# Altium schematic sheet ToolDoorController.SchDoc
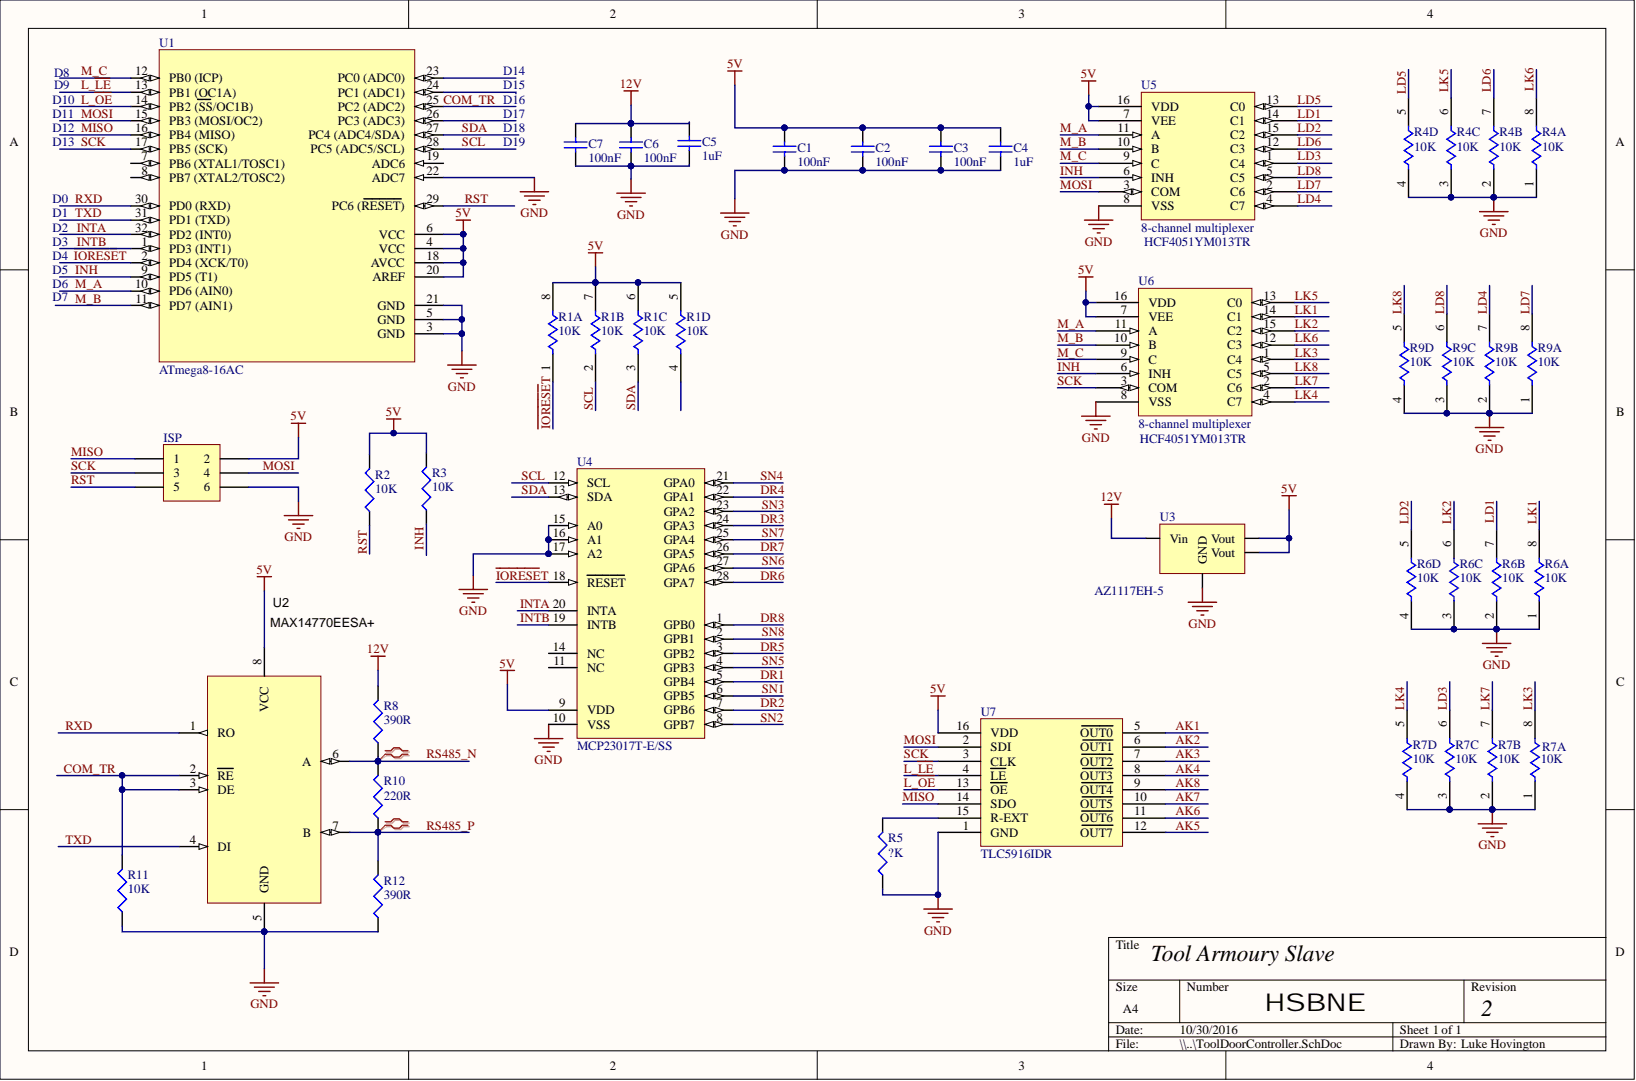
[diagram p0: ToolDoorController.SchDoc]

## Parts
C1  100nF  [C1206]
C2  100nF  [C1206]
C3  100nF  [C1206]
C4  1uF  [C1206]
C5  1uF  [C1206]
C6  100nF  [C1206]
C7  100nF  [C1206]
ISP  ISP  [HDR2X3]
R1  10K  [SO8_S]  (parts A,B,C,D)
R2  10K  [C1206]
R3  10K  [C1206]
R4  10K  [SO8_S]  (parts A,B,C,D)
R5  ?K  [C1206]
R6  10K  [SO8_S]  (parts A,B,C,D)
R7  10K  [SO8_S]  (parts A,B,C,D)
R8  390R  [C1206]  (no BOM)
R9  10K  [SO8_S]  (parts A,B,C,D)
R10  220R  [C1206]  (no BOM)
R11  10K  [C1206]
R12  390R  [C1206]  (no BOM)
U1  ATmega8-16AC  [32A_N]
U2  MAX14770EESA+  [8S1_L]
U3  AZ1117EH-5  [SOT-223]
U4  MCP23017T-E/SS  [SSOP-SS28_N]
U5  8-channel multiplexer  Manufacturer Part Number: HCF4051YM013TR  [16S1_M]
U6  8-channel multiplexer  Manufacturer Part Number: HCF4051YM013TR  [16S1_M]
U7  TLC5916IDR  [DB016]

## Nets
5V: C1.2 C2.2 C3.2 C4.2 ISP.2 R1.5 R1.6 R1.7 R1.8 R2.2 R3.2 U1.4(VCC) U1.6(VCC) U1.18(AVCC) U1.20(AREF) U2.8(VCC) U3.2(Vout) U3.4(Vout) U4.9(VDD) U5.7(VEE) U5.16(VDD) U6.7(VEE) U6.16(VDD) U7.16(VDD)
12V: C5.2 C6.2 C7.2 R8.2 U3.3(Vin)
GND: C1.1 C2.1 C3.1 C4.1 C5.1 C6.1 C7.1 ISP.6 R4.1 R4.2 R4.3 R4.4 R5.1 R6.1 R6.2 R6.3 R6.4 R7.1 R7.2 R7.3 R7.4 R9.1 R9.2 R9.3 R9.4 R11.1 R12.1 U1.3(GND) U1.5(GND) U1.21(GND) U1.22(ADC7) U2.5(GND) U3.1(GND) U4.10(VSS) U4.15(A0) U4.16(A1) U4.17(A2) U5.8(VSS) U6.8(VSS) U7.1(GND)
AK1: U7.5(OUT0)
AK2: U7.6(OUT1)
AK3: U7.7(OUT2)
AK4: U7.8(OUT3)
AK5: U7.12(OUT7)
AK6: U7.11(OUT6)
AK7: U7.10(OUT5)
AK8: U7.9(OUT4)
COM_TR: R11.2 U1.25(PC2_(ADC2)) U2.2(RE) U2.3(DE)
DR1: U4.5(GPB4)
DR2: U4.7(GPB6)
DR3: U4.24(GPA3)
DR4: U4.22(GPA1)
DR5: U4.3(GPB2)
DR6: U4.28(GPA7)
DR7: U4.26(GPA5)
DR8: U4.1(GPB0)
~{IORESE}T: R1.1 U1.2(PD4_(XCK/T0)) U4.18(RESET)
INH: R3.1 U1.9(PD5_(T1)) U5.6(INH) U6.6(INH)
INTA: U1.32(PD2_(INT0)) U4.20(INTA)
INTB: U1.1(PD3_(INT1)) U4.19(INTB)
L_~{LE}: U1.13(PB1_(OC1A)) U7.4(LE)
L_~{OE}: U1.14(PB2_(SS/OC1B)) U7.13(OE)
LD1: R6.7 U5.14(C1)
LD2: R6.5 U5.15(C2)
LD3: R7.6 U5.1(C4)
LD4: R9.7 U5.4(C7)
LD5: R4.5 U5.13(C0)
LD6: R4.7 U5.12(C3)
LD7: R9.8 U5.2(C6)
LD8: R9.6 U5.5(C5)
LK1: R6.8 U6.14(C1)
LK2: R6.6 U6.15(C2)
LK3: R7.8 U6.1(C4)
LK4: R7.5 U6.4(C7)
LK5: R4.6 U6.13(C0)
LK6: R4.8 U6.12(C3)
LK7: R7.7 U6.2(C6)
LK8: R9.5 U6.5(C5)
M_A: U1.10(PD6_(AIN0)) U5.11(A) U6.11(A)
M_B: U1.11(PD7_(AIN1)) U5.10(B) U6.10(B)
M_C: U1.12(PB0_(ICP)) U5.9(C) U6.9(C)
MISO: ISP.1 U1.16(PB4_(MISO)) U7.14(SDO)
MOSI: ISP.4 U1.15(PB3_(MOSI/OC2)) U5.3(COM) U7.2(SDI)
RS485_N: R8.1 R10.2 U2.6(A)
RS485_P: R10.1 R12.2 U2.7(B)
RST: ISP.5 R2.1 U1.29(PC6_(RESET))
RXD: U1.30(PD0_(RXD)) U2.1(RO)
SCK: ISP.3 U1.17(PB5_(SCK)) U6.3(COM) U7.3(CLK)
SCL: R1.2 U1.28(PC5_(ADC5/SCL)) U4.12(SCL)
SDA: R1.3 U1.27(PC4_(ADC4/SDA)) U4.13(SDA)
SN1: U4.6(GPB5)
SN2: U4.8(GPB7)
SN3: U4.23(GPA2)
SN4: U4.21(GPA0)
SN5: U4.4(GPB3)
SN6: U4.27(GPA6)
SN7: U4.25(GPA4)
SN8: U4.2(GPB1)
TXD: U1.31(PD1_(TXD)) U2.4(DI)
NetR5_2: R5.2 U7.15(R-EXT)

Not connected: R1 4; U1 7(PB6_(XTAL1/TOSC1)) 8(PB7_(XTAL2/TOSC2)) 19(ADC6) 23(PC0_(ADC0)) 24(PC1_(ADC1)) 26(PC3_(ADC3)); U4 11(NC) 14(NC)

## Library data of the parts
C1-C3 C6 C7 = Cap 100nF: Capacitor (Semiconductor SIM Model); Component Kind: Standard; LatestRevisionDate: 29-May-2009; LatestRevisionNote: IPC-7351 Footprint Added.; PackageDescription: Chip Capacitor; PackageReference: C1206; Published: 8-Jun-2000; Signal Integrity: Cap Semi; Simulation: CAP; Supplier 1: Digi-Key; Supplier 2: Mouser; Supplier Part Number 1: 399-1249-1-ND; Supplier Part Number 2: 80-C1206C104K5R; Value: 100nF; Library Name: ToolDoorController.SCHLIB; Publisher: Altium Limited
C4 C5 = Cap 1uF: Capacitor (Semiconductor SIM Model); Component Kind: Standard; LatestRevisionDate: 29-May-2009; LatestRevisionNote: IPC-7351 Footprint Added.; PackageDescription: Chip Capacitor; PackageReference: C1206; Published: 8-Jun-2000; Signal Integrity: Cap Semi; Simulation: CAP; Supplier 1: Mouser; Supplier 2: Digi-Key; Supplier Part Number 1: 80-C1206C105K5R; Supplier Part Number 2: 399-8150-1-ND; Value: 1uF; Library Name: ToolDoorController.SCHLIB; Publisher: Altium Limited
ISP = Header 3X2: Header, 3-Pin, Dual row; Component Kind: Standard; LatestRevisionDate: 17-Jul-2002; LatestRevisionNote: Re-released for DXP Platform.; Signal Integrity: Connector; Supplier 1: Digi-Key; Supplier 2: Mouser; Supplier Part Number 1: 952-2121-ND; Supplier Part Number 2: 855-M20-9980346; Library Name: ToolDoorController.SCHLIB; Publisher: Altium Limited
R1 R4 R6 R7 R9 = Resistor Pack: Isolated Resistor Network - Parts; Sim Note: Enter the Resistance value into parameter field.; Code_IPC: SOIC127P600-16; Code_JEDEC: MS-012-AC; Component Kind: Standard; LatestRevisionDate: 29-May-2009; LatestRevisionNote: IPC-7351 Footprint Added.; PackageDescription: 16-Pin Small Outline Integrated Circuit 1.27 mm Pitch; PackageReference: SOIC16; Published: 24-Mar-1999; Signal Integrity: Res Pack; Simulation: RESISTOR; Supplier 1: Digi-Key; Supplier 2: Mouser; Value: 10K; Library Name: ToolDoorController.SCHLIB; Publisher: Altium Limited
R2 R3 R11 = Resistor-10K: Resistor; Component Kind: Standard; LatestRevisionDate: 29-May-2009; LatestRevisionNote: IPC-7351 Footprint Added.; PackageDescription: Chip Resistor; PackageReference: J1-0603; Published: 8-Jun-2000; Signal Integrity: Res; Simulation: RESISTOR; Supplier 1: Digi-Key; Supplier 2: Mouser; Supplier Part Number 1: P10KECT-ND; Supplier Part Number 2: 71-CRCW1206-10K-E3; Value: 10K; Library Name: ToolDoorController.SCHLIB; Publisher: Altium Limited
R5 = Resistor-10K: Resistor; Value: 10K; Component Kind: Standard; LatestRevisionDate: 29-May-2009; LatestRevisionNote: IPC-7351 Footprint Added.; PackageDescription: Chip Resistor; PackageReference: J1-0603; Published: 8-Jun-2000; Signal Integrity: Res; Simulation: RESISTOR; Supplier 1: Digi-Key; Supplier 2: Mouser; Supplier Part Number 1: P10KECT-ND; Supplier Part Number 2: 71-CRCW1206-10K-E3; Library Name: ToolDoorController.SCHLIB; Publisher: Altium Limited
R8 R12 = Resistor-390R: Resistor; LatestRevisionDate: 29-May-2009; LatestRevisionNote: IPC-7351 Footprint Added.; PackageDescription: Chip Resistor; PackageReference: J1-0603; Published: 8-Jun-2000; Signal Integrity: Res; Simulation: RESISTOR; Supplier 1: Digi-Key; Supplier Part Number 1: RMCF1206JT390RCT-ND; Value: 390R; Library Name: ToolDoorController.SCHLIB; Publisher: Altium Limited
R10 = Resistor-220R: Resistor; LatestRevisionDate: 29-May-2009; LatestRevisionNote: IPC-7351 Footprint Added.; PackageDescription: Chip Resistor; PackageReference: J1-0603; Published: 8-Jun-2000; Signal Integrity: Res; Simulation: RESISTOR; Supplier 1: Digi-Key; Supplier Part Number 1: RMCF1206JT220RCT-ND; Value: 220R; Library Name: ToolDoorController.SCHLIB; Publisher: Altium Limited
U1 = ATmega8-16AC: 8-Bit AVR Microcontroller with 8K Bytes of In-System Programmable Flash Memory; Code_JEDEC: MS-026-ABA; Component Kind: Standard; ComponentLink1Description: Datasheet; ComponentLink1URL: http://www.atmel.com/dyn/resources/prod_documents/doc2486.pdf; ComponentLink2Description: Atmel.com Home; ComponentLink2URL: http://www.atmel.com; DatasheetVersion: 2486H-AVR-09/02; LatestRevisionDate: 16-Oct-2006; LatestRevisionNote: IPC-7351 Footprint Added.; PackageDescription: 32-Lead, 7x7x1.0 mm Body, 0.8mm Lead Pitch, Thin Profile Plastic Quad Flat Package (TQFP); PackageReference: 32A; PackageVersion: revB, Oct-2001; Published: 17-Sep-2003; Supplier 1: Digi-Key; Supplier 2: Mouser; Supplier Part Number 1: ATMEGA8-16AC-ND; Supplier Part Number 2: 556-ATMEGA8-16AC; Library Name: ToolDoorController.SCHLIB; Publisher: Altium Limited
U2 = MAX14770EESA+: TXRX PROFIBUS RS-485 8-SOIC; Component Kind: Standard; MPN: MAX14770EESA+; Supplier 1: Mouser; Supplier 2: Digi-Key; Supplier Part Number 1: 700-MAX14770EESA+; Supplier Part Number 2: MAX14770EESA+-ND; Library Name: ToolDoorController.SCHLIB
U3 = AZ1117EH: Reg 1A 5V OUT SIP VERT; Component Kind: Standard; Supplier 1: Digi-Key; Supplier Part Number 1: AZ1117EH-5.0TRG1DICT-ND; Library Name: ToolDoorController.SCHLIB
U4 = CMP-0186-00065-2: 16-Bit I/O Expander with Serial Interface, 28-Pin SSOP, Extended Temperature, Tape and Reel; Code_JEDEC: MS-150; Component Kind: Standard; ComponentLink1Description: Manufacturer URL; ComponentLink1URL: http://www.microchip.com/; ComponentLink2Description: Datasheet; ComponentLink2URL: http://ww1.microchip.com/downloads/en/DeviceDoc/21952b.pdf; ComponentLink3Description: Package Specification; ComponentLink3URL: http://www.microchip.com/stellent/groups/techpub_sg/documents/packagingspec/en012702.pdf; DatasheetVersion: revB, Feb-2007; Library Name: Altium Content Vault; PackageDescription: 28-Lead Plastic Shrink Small Outline (SS) - 5.3mm Body [SSOP]; PackageReference: SSOP-SS28; PackageVersion: revBB, Aug-2009; RevisionNote: URLs added.
U5 U6 = 8-channel multiplexer: 8-channel analog multiplexer/demultiplexer; Component Kind: Standard; Supplier 1: Digi-Key; Supplier Part Number 1: 497-14372-1-ND; Library Name: ToolDoorController.SCHLIB
U7 = TLC5916IDR: IC LED DRIVER LIN 120MA; Component Kind: Standard; Supplier 1: Digi-Key; Supplier Part Number 1: 296-22711-1-ND; Library Name: ToolDoorController.SCHLIB
